# Altium schematic sheet SFCRS232.SchDoc(SFCRS232)
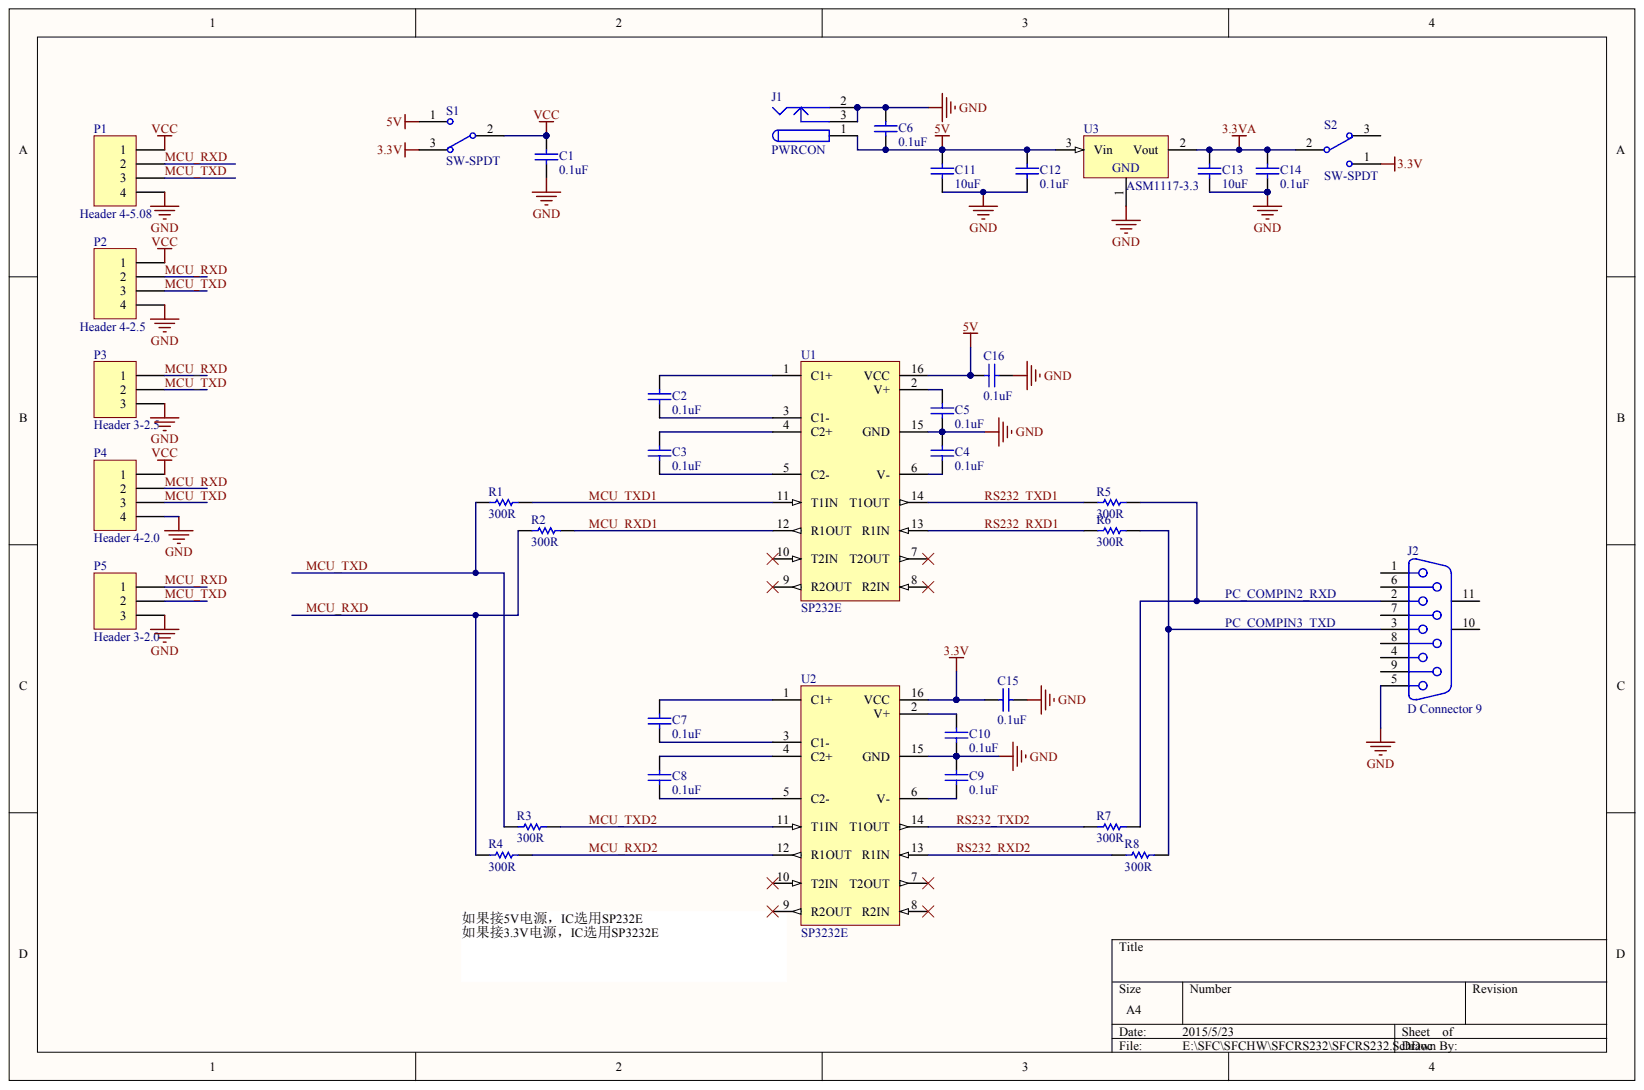
[diagram p0: SFCRS232.SchDoc]

## Parts
C1  0.1uF
C2  0.1uF
C3  0.1uF
C4  0.1uF
C5  0.1uF
C6  0.1uF
C7  0.1uF
C8  0.1uF
C9  0.1uF
C10  0.1uF
C11  10uF
C12  0.1uF
C13  10uF
C14  0.1uF
C15  0.1uF
C16  0.1uF
J1  PWRCON
J2  D Connector 9
P1  Header 4-5.08
P2  Header 4-2.5
P3  Header 3-2.5
P4  Header 4-2.0
P5  Header 3-2.0
R1  300R
R2  300R
R3  300R
R4  300R
R5  300R
R6  300R
R7  300R
R8  300R
S1  SW-SPDT
S2  SW-SPDT
U1  SP232E
U2  SP3232E
U3  ASM1117-3.3

## Nets
3.3V: C15.2 S1.3 S2.1 U2.16(VCC)
3.3VA: C13.2 C14.2 S2.2 U3.2
5V: C6.1 C11.2 C12.2 C16.2 J1.1 S1.1 U1.16(VCC) U3.3
GND: C1.1 C4.1 C5.2 C6.2 C9.2 C10.2 C11.1 C12.1 C13.1 C14.1 C15.1 C16.1 J1.2 J1.3 J2.5 P1.4 P2.4 P3.3 P4.4 P5.3 U1.15(GND) U2.15(GND) U3.1
VCC: C1.2 P1.1 P2.1 P4.1 S1.2
MCU_RXD: P1.2 P2.2 P3.1 P4.2 P5.1 R2.1 R4.1
MCU_RXD1: R2.2 U1.12(R1OUT)
MCU_RXD2: R4.2 U2.12(R1OUT)
MCU_TXD: P1.3 P2.3 P3.2 P4.3 P5.2 R1.1 R3.1
MCU_TXD1: R1.2 U1.11(T1IN)
MCU_TXD2: R3.2 U2.11(T1IN)
RS232_RXD1: R6.1 U1.13(R1IN)
RS232_RXD2: R8.1 U2.13(R1IN)
RS232_TXD1: R5.1 U1.14(T1OUT)
RS232_TXD2: R7.1 U2.14(T1OUT)
NetC2_1: C2.1 U1.3(C1-)
NetC2_2: C2.2 U1.1(C1+)
NetC3_1: C3.1 U1.5(C2-)
NetC3_2: C3.2 U1.4(C2+)
NetC4_2: C4.2 U1.6(V-)
NetC5_1: C5.1 U1.2(V+)
NetC7_1: C7.1 U2.3(C1-)
NetC7_2: C7.2 U2.1(C1+)
NetC8_1: C8.1 U2.5(C2-)
NetC8_2: C8.2 U2.4(C2+)
NetC9_1: C9.1 U2.6(V-)
NetC10_1: C10.1 U2.2(V+)
NetJ2_2: J2.2 R5.2 R7.2
NetJ2_3: J2.3 R6.2 R8.2

Not connected: J2 1 4 6 7 8 9 10 11; S2 3; U1 7(T2OUT) 8(R2IN) 9(R2OUT) 10(T2IN); U2 7(T2OUT) 8(R2IN) 9(R2OUT) 10(T2IN)

## Library data of the parts
C1-C16 = (no symbol name): Value: 1nF; Published: 8-Jun-2000; LatestRevisionDate: 17-Jul-2002; LatestRevisionNote: Re-released for DXP Platform.; PackageReference: RAD-0.3; Publisher: Altium Limited
J2 = (no symbol name): PackageDocument: O; Positions: 9; Gender: Receptacle; Published: 15-Jun-2001; LatestRevisionDate: 17-Jul-2002; LatestRevisionNote: Re-released for DXP Platform.; DatasheetDocument: 1-Sep-1999; PackageReference: 788750; Orientation: Right Angle; Publisher: Altium Limited
R1-R8 = (no symbol name): Value: 10K; Published: 8-Jun-2000; LatestRevisionDate: 17-Jul-2002; LatestRevisionNote: Re-released for DXP Platform.; PackageReference: AXIAL-0.3; Publisher: Altium Limited
J1 P1-P5 S1 S2 U1-U3 = (no symbol name)
  J1: Published: 17-Dec-2003; DatasheetDocument: 09-May-2002; ComponentLink1Description: Manufacturer Link; ComponentLink1URL: http://domino.kycon.com/internet/Products.html
  P1-P5: LatestRevisionDate: 17-Jul-2002; LatestRevisionNote: Re-released for DXP Platform.
  S1 S2: Published: 24-Mar-1999; LatestRevisionDate: 17-Dec-2003; LatestRevisionNote: Schematic revised, PCB Footprint replaced.; DatasheetDocument: 05-Dec-2000; PackageReference: TL36WW15050; ComponentLink1Description: Manufacturer Link; ComponentLink1URL: http://www.apem.com
  U1 U2: Published: 16-Jan-2001; LatestRevisionDate: 13-Apr-2006; LatestRevisionNote: IPC-7351 Footprint Added.; PackageReference: NSO16; PackageDescription: 16-Pin Small Outline Integrated Circuit 1.27 mm Pitch; Code_JEDEC: MS-012-AC; Code_IPC: SOIC127P600-16; ComponentLink1Description: Manufacturer Link; ComponentLink1URL: http://www.maxim-ic.com/; ComponentLink2Description: Datasheet; ComponentLink2URL: http://pdfserv.maxim-ic.com/en/ds/MAX220-MAX249.pdf
  J1 P1-P5 S1 S2 U1 U2: Publisher: Altium Limited
